# Altium schematic sheet main.SchDoc
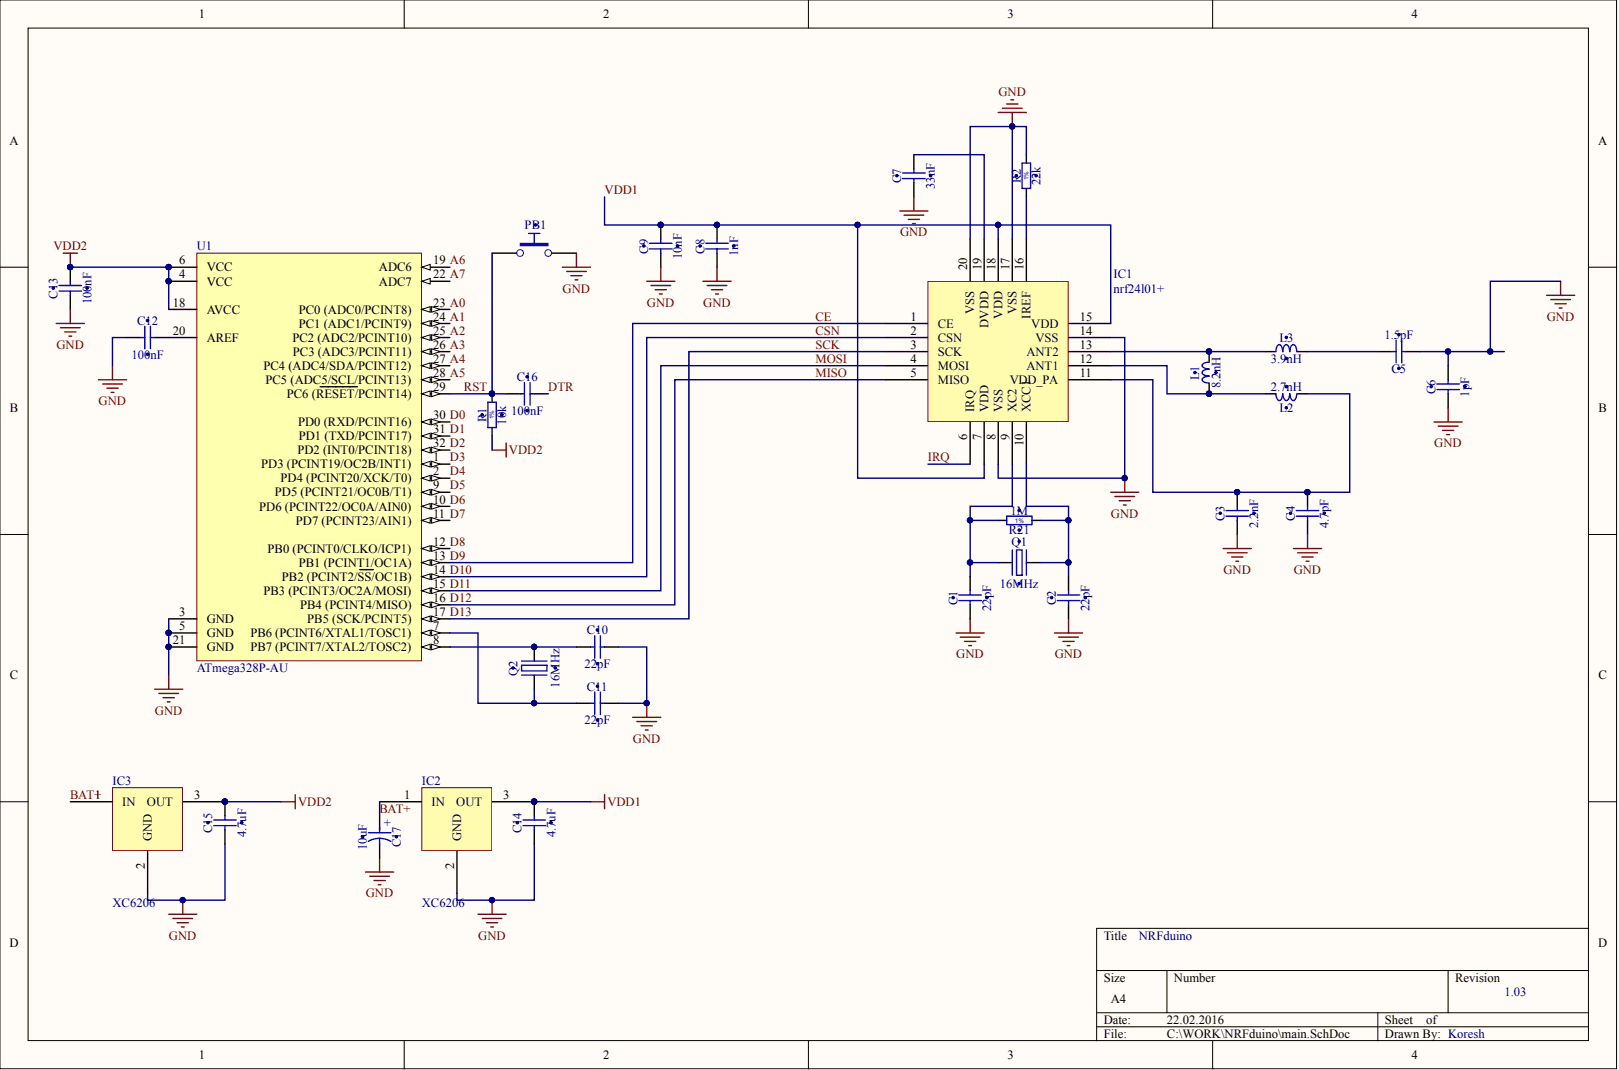
[diagram p0: main.SchDoc]

## Parts
C1  22pF  [CAPC1608X87N]
C2  22pF  [CAPC1608X87N]
C3  2.2nF  [CAPC1005X55N]
C4  4.7pF  [CAPC1005X55N]
C5  1.5pF  [CAPC1005X55N]
C6  1pF  [CAPC1005X55N]
C7  33nF  [CAPC1005X55N]
C8  1nF  [CAPC1005X55N]
C9  10nF  [CAPC1005X55N]
C10  22pF  [CAPC1608X87N]
C11  22pF  [CAPC1608X87N]
C12  100nF  [CAPC1608X87N]
C13  100nF  [CAPC1608X90N]
C14  4.7uF  [CAPC2012X135N]
C15  4.7uF  [CAPC2012X135N]
C16  100nF  [CAPC1608X87N]
C17  10uF  [CAPMP3216X180N]
IC1  nrf24l01+  [QFN-ML20_M]
IC2  XC6206  [XC6206]
IC3  XC6206  [XC6206]
L1  8.2nH  [INDC1005X55N]
L2  2.7nH  [INDC1005X55N]
L3  3.9nH  [INDC1005X55N]
PB1  Push Button  [PB_TYCO_FSMSM]  (value not drawn)
Q1  16MHz  [XTAL1140X470X160N]
Q2  16MHz  [XTAL_CITIZEN_HCM49]
R1  10k  [RESC1608X55N]
R2  22k  [RESC1005X40N]
R21  1M  [RESC1005X40N]
U1  ATmega328P-AU  [32A_N]

## Nets
GND: C1.1 C2.1 C3.1 C4.1 C5.1 C6.1 C6.2 C7.1 C8.1 C9.1 C10.2 C11.2 C12.1 C13.1 C14.1 C15.1 C17.2 IC1.8(VSS) IC1.14(VSS) IC1.17(VSS) IC1.20(VSS) IC2.2 IC3.2 PB1.2 R2.2 U1.3(GND) U1.5(GND) U1.21(GND)
VDD2: C13.2 C15.2 IC3.3 R1.1 U1.4(VCC) U1.6(VCC) U1.18(AVCC)
A0: U1.23(PC0_(ADC0/PCINT8))
A1: U1.24(PC1_(ADC1/PCINT9))
A2: U1.25
A3: U1.26(PC3_(ADC3/PCINT11))
A4: U1.27(PC4_(ADC4/SDA/PCINT12))
A5: U1.28(PC5_(ADC5/SCL/PCINT13))
A6: U1.19(ADC6)
A7: U1.22(ADC7)
BAT+: C17.1 IC2.1 IC3.1
CE: IC1.1(CE) U1.13(PB1_(PCINT1/OC1A))
CSN: IC1.2(CSN) U1.14(PB2_(PCINT2/SS/OC1B))
D0: U1.30(PD0_(RXD/PCINT16))
D1: U1.31(PD1_(TXD/PCINT17))
D2: U1.32(PD2_(INT0/PCINT18))
D3: U1.1(PD3_(PCINT19/OC2B/INT1))
D4: U1.2(PD4_(PCINT20/XCK/T0))
D5: U1.9(PD5_(PCINT21/OC0B/T1))
D6: U1.10(PD6_(PCINT22/OC0A/AIN0))
D7: U1.11(PD7_(PCINT23/AIN1))
D8: U1.12(PB0_(PCINT0/CLKO/ICP1))
D11: IC1.4(MOSI) U1.15(PB3_(PCINT3/OC2A/MOSI))
D12: IC1.5(MISO) U1.16(PB4_(PCINT4/MISO))
D13: IC1.3(SCK) U1.17(PB5_(SCK/PCINT5))
DTR: C16.2
IRQ: IC1.6(IRQ)
RST: C16.1 PB1.1 R1.2 U1.29(PC6_(RESET/PCINT14))
VDD1: C8.2 C9.2 C14.2 IC1.7(VDD) IC1.15(VDD) IC1.18(VDD) IC2.3
NetC1_2: C1.2 IC1.9(XC2) Q1.1 R21.2
NetC2_2: C2.2 IC1.10(XCC1) Q1.2 R21.1
NetC3_2: C3.2 C4.2 IC1.11(VDD_PA) L2.1
NetC5_2: C5.2 L3.2
NetC7_2: C7.2 IC1.19(DVDD)
NetC10_1: C10.1 Q2.2 U1.8
NetC11_1: C11.1 Q2.1 U1.7
NetC12_2: C12.2 U1.20(AREF)
NetIC1_12: IC1.12(ANT1) L1.1 L2.2
NetIC1_13: IC1.13(ANT2) L1.2 L3.1
NetIC1_16: IC1.16(IREF) R2.1

## Library data of the parts
C1 C2 C10 C11 = CC0603_22PF_50V_5%_NP0: Non-polarised capacitor; Manufacturer: GENERIC; Manufacturer1 Part Number: 223886715229; Part Description: SMD Multilayer Chip Ceramic Capacitor; Database Table Name: Capacitors SMD; TC: NP0; Tolerance: ±5%; Voltage: 50V; Case: 0603; Component Kind: Standard; Component Type: Standard; CreateDate: 03.12.2007; HelpURL: \\cern.ch\dfs\Applications\Altium\Datasheets\CC0603_NP0_PHYCOMP.pdf; LatestRevisionDate: 03.12.2007; Library Name: Capacitors.DbLib; Manufacturer1 ComponentHeight: 0.87mm; Manufacturer1 Example: PHYCOMP; Manufacturer Part Number: CC0603_22PF_50V_5%_NP0; Mounted: Yes; Part Number: CC0603_22PF_50V_5%_NP0; Pin Count: 2; SMD: Yes; Socket: No; Status: Preferred; Table: Capacitors SMD; Value: 22pF; Author: CERN DEM JLC
C3 = CC0402_2.2NF_50V_10%_X7R: Non-polarised capacitor; Manufacturer: GENERIC; Manufacturer1 Part Number: 223858715627; Part Description: SMD Multilayer Chip Ceramic Capacitor; Database Table Name: Capacitors SMD; TC: X7R; Tolerance: ±10%; Voltage: 50V; Case: 0402; Component Kind: Standard; Component Type: Standard; CreateDate: 03.12.2007; HelpURL: \\cern.ch\dfs\Applications\Altium\Datasheets\CC0402_X7R_PHYCOMP.pdf; LatestRevisionDate: 03.12.2007; Library Name: Capacitors.DbLib; Manufacturer1 ComponentHeight: 0.55mm; Manufacturer1 Example: PHYCOMP; Manufacturer Part Number: CC0402_2.2NF_50V_10%_X7R; Mounted: Yes; Part Number: CC0402_2.2NF_50V_10%_X7R; Pin Count: 2; SMD: Yes; Socket: No; Status: Not Recommended; Table: Capacitors SMD; Value: 2.2nF; Author: CERN DEM JLC
C4 = CC0402_4.7PF_50V_0.25PF_NP0: Non-polarised capacitor; Manufacturer: GENERIC; Manufacturer1 Part Number: 223886915478; Part Description: SMD Multilayer Chip Ceramic Capacitor; Database Table Name: Capacitors SMD; TC: NP0; Tolerance: ±0.25pF; Voltage: 50V; Case: 0402; Component Kind: Standard; Component Type: Standard; CreateDate: 03.12.2007; HelpURL: \\cern.ch\dfs\Applications\Altium\Datasheets\CC0402_NP0_PHYCOMP.pdf; LatestRevisionDate: 03.12.2007; Library Name: Capacitors.DbLib; Manufacturer1 ComponentHeight: 0.55mm; Manufacturer1 Example: PHYCOMP; Manufacturer Part Number: CC0402_4.7PF_50V_0.25PF_NP0; Mounted: Yes; Part Number: CC0402_4.7PF_50V_0.25PF_NP0; Pin Count: 2; SMD: Yes; Socket: No; Status: Not Recommended; Table: Capacitors SMD; Value: 4.7pF; Author: CERN DEM JLC
C5 = CC0402_1.5PF_50V_0.25PF_NP0: Non-polarised capacitor; Manufacturer: GENERIC; Manufacturer1 Part Number: 223886915158; Part Description: SMD Multilayer Chip Ceramic Capacitor; Database Table Name: Capacitors SMD; TC: NP0; Tolerance: ±0.25pF; Voltage: 50V; Case: 0402; Component Kind: Standard; Component Type: Standard; CreateDate: 03.12.2007; HelpURL: \\cern.ch\dfs\Applications\Altium\Datasheets\CC0402_NP0_PHYCOMP.pdf; LatestRevisionDate: 03.12.2007; Library Name: Capacitors.DbLib; Manufacturer1 ComponentHeight: 0.55mm; Manufacturer1 Example: PHYCOMP; Manufacturer Part Number: CC0402_1.5PF_50V_0.25PF_NP0; Mounted: Yes; Part Number: CC0402_1.5PF_50V_0.25PF_NP0; Pin Count: 2; SMD: Yes; Socket: No; Status: Not Recommended; Table: Capacitors SMD; Value: 1.5pF; Author: CERN DEM JLC
C6 = CC0402_1PF_50V_0.25PF_NP0: Non-polarised capacitor; Manufacturer: GENERIC; Manufacturer1 Part Number: 223886915108; Part Description: SMD Multilayer Chip Ceramic Capacitor; Database Table Name: Capacitors SMD; TC: NP0; Tolerance: ±0.25pF; Voltage: 50V; Case: 0402; Component Kind: Standard; Component Type: Standard; CreateDate: 03.12.2007; HelpURL: \\cern.ch\dfs\Applications\Altium\Datasheets\CC0402_NP0_PHYCOMP.pdf; LatestRevisionDate: 03.12.2007; Library Name: Capacitors.DbLib; Manufacturer1 ComponentHeight: 0.55mm; Manufacturer1 Example: PHYCOMP; Manufacturer Part Number: CC0402_1PF_50V_0.25PF_NP0; Mounted: Yes; Part Number: CC0402_1PF_50V_0.25PF_NP0; Pin Count: 2; SMD: Yes; Socket: No; Status: Not Recommended; Table: Capacitors SMD; Value: 1pF; Author: CERN DEM JLC
C7 = CC0402_33NF_16V_10%_X7R: Non-polarised capacitor; Manufacturer: GENERIC; Manufacturer1 Part Number: 223878715643; Part Description: SMD Multilayer Chip Ceramic Capacitor; Database Table Name: Capacitors SMD; TC: X7R; Tolerance: ±10%; Voltage: 16V; Case: 0402; Component Kind: Standard; Component Type: Standard; CreateDate: 03.12.2007; HelpURL: \\cern.ch\dfs\Applications\Altium\Datasheets\CC0402_X7R_PHYCOMP.pdf; LatestRevisionDate: 03.12.2007; Library Name: Capacitors.DbLib; Manufacturer1 ComponentHeight: 0.55mm; Manufacturer1 Example: PHYCOMP; Manufacturer Part Number: CC0402_33NF_16V_10%_X7R; Mounted: Yes; Part Number: CC0402_33NF_16V_10%_X7R; Pin Count: 2; SMD: Yes; Socket: No; Status: Not Recommended; Table: Capacitors SMD; Value: 33nF; Author: CERN DEM JLC
C8 = CC0402_1NF_50V_10%_X7R: Non-polarised capacitor; Manufacturer: GENERIC; Manufacturer1 Part Number: 223858715623; Part Description: SMD Multilayer Chip Ceramic Capacitor; Database Table Name: Capacitors SMD; TC: X7R; Tolerance: ±10%; Voltage: 50V; Case: 0402; Component Kind: Standard; Component Type: Standard; CreateDate: 03.12.2007; HelpURL: \\cern.ch\dfs\Applications\Altium\Datasheets\CC0402_X7R_PHYCOMP.pdf; LatestRevisionDate: 03.12.2007; Library Name: Capacitors.DbLib; Manufacturer1 ComponentHeight: 0.55mm; Manufacturer1 Example: PHYCOMP; Manufacturer Part Number: CC0402_1NF_50V_10%_X7R; Mounted: Yes; Part Number: CC0402_1NF_50V_10%_X7R; Pin Count: 2; SMD: Yes; Socket: No; Status: Not Recommended; Table: Capacitors SMD; Value: 1nF; Author: CERN DEM JLC
C9 = CC0402_10NF_16V_10%_X7R: Non-polarised capacitor; Manufacturer: GENERIC; Manufacturer1 Part Number: 223878715636; Part Description: SMD Multilayer Chip Ceramic Capacitor; Database Table Name: Capacitors SMD; TC: X7R; Tolerance: ±10%; Voltage: 16V; Case: 0402; Component Kind: Standard; Component Type: Standard; CreateDate: 03.12.2007; HelpURL: \\cern.ch\dfs\Applications\Altium\Datasheets\CC0402_X7R_PHYCOMP.pdf; LatestRevisionDate: 03.12.2007; Library Name: Capacitors.DbLib; Manufacturer1 ComponentHeight: 0.55mm; Manufacturer1 Example: PHYCOMP; Manufacturer Part Number: CC0402_10NF_16V_10%_X7R; Mounted: Yes; Part Number: CC0402_10NF_16V_10%_X7R; Pin Count: 2; SMD: Yes; Socket: No; Status: Not Recommended; Table: Capacitors SMD; Value: 10nF; Author: CERN DEM JLC
C12 C16 = CC0603_100NF_50V_10%_X7R: Non-polarised capacitor; Manufacturer: GENERIC; Manufacturer1 Part Number: 223858615649; Part Description: SMD Multilayer Chip Ceramic Capacitor; Database Table Name: Capacitors SMD; TC: X7R; Tolerance: ±10%; Voltage: 50V; Case: 0603; Component Kind: Standard; Component Type: Standard; CreateDate: 03.12.2007; HelpURL: \\cern.ch\dfs\Applications\Altium\Datasheets\CC0603_X7R_PHYCOMP.pdf; LatestRevisionDate: 03.12.2007; Library Name: Capacitors.DbLib; Manufacturer1 ComponentHeight: 0.87mm; Manufacturer1 Example: PHYCOMP; Manufacturer Part Number: CC0603_100NF_50V_10%_X7R; Mounted: Yes; Part Number: CC0603_100NF_50V_10%_X7R; Pin Count: 2; SMD: Yes; Socket: No; Status: Preferred; Table: Capacitors SMD; Value: 100nF; Author: CERN DEM JLC
C13 = CC0603_100NF_100V_10%_X7R: Non-polarised capacitor; Manufacturer: GENERIC; Manufacturer1 Part Number: GRM188R72A104KA35D; Part Description: SMD Multilayer Chip Ceramic Capacitor; Database Table Name: Capacitors SMD; TC: X7R; Tolerance: ±10%; Voltage: 100V; Case: 0603; Component Kind: Standard; Component Type: Standard; CreateDate: 11.12.2013; HelpURL: \\cern.ch\dfs\Applications\Altium\Datasheets\CC0603_MURATA_GRM188R72A104KA35D.pdf; LatestRevisionDate: 11.12.2013; Library Name: Capacitors.DbLib; Manufacturer1 ComponentHeight: 0.9mm; Manufacturer1 Example: MURATA; Manufacturer Part Number: CC0603_100NF_100V_10%_X7R; Mounted: Yes; Part Number: CC0603_100NF_100V_10%_X7R; Pin Count: 2; SMD: Yes; Socket: No; Status: None; Table: Capacitors SMD; Value: 100nF; Author: CERN DEM JLC
C14 C15 = CC0805_4.7UF_10V_10%_X5R: Non-polarised capacitor; Manufacturer: GENERIC; Manufacturer1 Part Number: 222224013672; Part Description: SMD Multilayer Chip Ceramic Capacitor; Database Table Name: Capacitors SMD; TC: X5R; Tolerance: ±10%; Voltage: 10V; Case: 0805; Component Kind: Standard; Component Type: Standard; CreateDate: 03.12.2007; HelpURL: \\cern.ch\dfs\Applications\Altium\Datasheets\CC0805_X5R_PHYCOMP_HC.pdf; LatestRevisionDate: 03.12.2007; Library Name: Capacitors.DbLib; Manufacturer1 ComponentHeight: 1.45mm; Manufacturer1 Example: PHYCOMP; Manufacturer Part Number: CC0805_4.7UF_10V_10%_X5R; Mounted: Yes; Part Number: CC0805_4.7UF_10V_10%_X5R; Pin Count: 2; SMD: Yes; Socket: No; Status: Preferred; Table: Capacitors SMD; Value: 4.7uF; Author: CERN DEM JLC
C17 = CTE3216-18_10UF_16V_20%_AVX_TCJ: Polarised capacitor; Manufacturer: AVX; Manufacturer Part Number: TCJA106M016R0200; Part Description: ESR 0R200 Tantalum Solid Electrolytic Chip Capacitor with Conductive Polymer Electrode; Database Table Name: Capacitors SMD; Tolerance: ±20%; Voltage: 16V; Case: A; ComponentHeight: 1.8mm; Component Kind: Standard; Component Type: Standard; CreateDate: 29.03.2012; HelpURL: \\cern.ch\dfs\Applications\Altium\Datasheets\CTE_AVX_TCJ.pdf; LatestRevisionDate: 29.03.2012; Library Name: Capacitors.DbLib; Mounted: Yes; Part Number: CTE3216-18_10UF_16V_20%_AVX_TCJ; Pin Count: 2; SMD: Yes; Socket: No; Status: Preferred; Table: Capacitors SMD; Value: 10uF; Author: CERN DEM JLC
L1 = IND0402_MURATA_BLM15HB221SN1: Inductor; Manufacturer: MURATA; Part Description: SMD EMIFIL Suppression Chip Ferrite Bead; Database Table Name: Inductors SMD; Power: 250mA; Resistance: 1R; Tolerance: ±25%; Case: 0402; ComponentHeight: 0.55mm; Component Kind: Standard; Component Type: Standard; CreateDate: 23.06.2009; HelpURL: \\cern.ch\dfs\Applications\Altium\Datasheets\IND0402_MURATA_BLM15H.pdf; LatestRevisionDate: 23.06.2009; Library Name: Inductors & Transformers.DbLib; Manufacturer Part Number: BLM15HB221SN1; Mounted: Yes; Part Number: IND0402_MURATA_BLM15HB221SN1; Pin Count: 2; SMD: Yes; Socket: No; Status: None; Table: Inductors SMD; Value: 8.2nH; Author: CERN DEM JLC
L2 = IND0402_MURATA_BLM15PX121SN1D: Inductor; Manufacturer: MURATA; Part Description: SMD EMIFIL Chip Ferrite Bead; Database Table Name: Inductors SMD; Power: 2A; Resistance: 0.055R; Tolerance: ±25%; Case: 0402; ComponentHeight: 0.55mm; Component Kind: Standard; Component Type: Standard; CreateDate: 19.02.2014; HelpURL: \\cern.ch\dfs\Applications\Altium\Datasheets\IND0402_MURATA_BLM15PX.pdf; LatestRevisionDate: 19.02.2014; Library Name: Inductors & Transformers.DbLib; Manufacturer Part Number: BLM15PX121SN1D; Mounted: Yes; Part Number: IND0402_MURATA_BLM15PX121SN1D; Pin Count: 2; SMD: Yes; Socket: No; Status: None; Table: Inductors SMD; Value: 2.7nH; Author: CERN DEM JMW
L3 = IND0402_MURATA_BLM15HD102SN1: Inductor; Manufacturer: MURATA; Manufacturer Part Number: BLM15HD102SN1D; Part Description: SMD EMIFIL Suppression Chip Ferrite Bead; Database Table Name: Inductors SMD; Power: 250mA; Resistance: 1.25R; Tolerance: ±25%; Case: 0402; ComponentHeight: 0.55mm; Component Kind: Standard; Component Type: Standard; CreateDate: 06.03.2012; HelpURL: \\cern.ch\dfs\Applications\Altium\Datasheets\IND0402_MURATA_BLM15H.pdf; LatestRevisionDate: 06.03.2012; Library Name: Inductors & Transformers.DbLib; Mounted: Yes; Part Number: IND0402_MURATA_BLM15HD102SN1; Pin Count: 2; SMD: Yes; Socket: No; Status: None; Table: Inductors SMD; Value: 3.9nH; Author: CERN DEM JLC
PB1 = PB_TYCO_FSMSM: Push-Button Switch SPDT; Manufacturer: TYCO ELECTRONICS; Manufacturer Part Number: FSMSM or 1437566-3; Part Description: 12VDC 50mA SPST Tactile  Push-Button Switch; Database Table Name: Switches; ComponentHeight: 4.3mm; Component Kind: Standard; Component Type: Standard; CreateDate: 30.06.2008; Family: Push Button; HelpURL: \\cern.ch\dfs\Applications\Altium\Datasheets\PB_TYCO_FSMSM.pdf; LatestRevisionDate: 21.06.2012; Library Name: Eletro-mechanical.DbLib; Mounted: Yes; PackageDescription: Body 3.5x6.0mm, Pushbutton Switch,  TYCO ALCOSWITCH FSMSM Serie; Part Number: PB_TYCO_FSMSM; Pin Count: 2; SMD: Yes; Socket: No; Status: None; Table: Switches; Author: CERN DEM JLC
Q1 = XTAL_25MHZ_ABRACON_ABLS-25.000MHZ-B2F-T: Quartz Crystal; Part Description: ±20ppm Low Profile Surface Mount Microprocessor Crystal, HC49 US ABLS Serie; Database Table Name: Crystals & Oscillators; ComponentHeight: 1.6mm; Component Kind: Standard; Component Type: Standard; CreateDate: 03.06.2010; HelpURL: \\cern.ch\dfs\Applications\Altium\Datasheets\XTAL_ABRACON_ABLS.pdf; LatestRevisionDate: 09.01.2012; Library Name: ICs And Semiconductors.DbLib; Manufacturer: ABRACON; Manufacturer Part Number: ABLS-25.000MHZ-B2F-T; Mounted: Yes; PackageDescription: HC49US Crystal;11.40mm L X 4.70mm W X 1.60mm H; Part Number: XTAL_25MHZ_ABRACON_ABLS-25.000MHZ-B2F-T; Pin Count: 2; SMD: Yes; Socket: No; Table: Crystals & Oscillators; Value: 16MHz; Author: CERN DEM JLC
Q2 = XTAL_24MHZ_CITIZEN_HCM49-24.000MABJT: Quartz Crystal; Manufacturer: CITIZEN FINETECH MIYOTA CO; Part Description: Tolerance:± 30ppm, Stability:± 50ppm, -10°C to +60°C, 18pF, AT-CUT Crystal Unit, HCM49 Series; Database Table Name: Crystals & Oscillators; ComponentHeight: 4.3mm; Component Kind: Standard; Component Type: Standard; CreateDate: 22.07.2014; HelpURL: \\cern.ch\dfs\Applications\Altium\Datasheets\XTAL_CITIZEN_HCM49.pdf; LatestRevisionDate: 22.07.2014; Library Name: ICs And Semiconductors.DbLib; Manufacturer Part Number: HCM49-24.000MABJT; Mounted: Yes; PackageDescription: CITIZEN HCM49 Case, SMD Cristal, Body 11.4 x 4.7mm; Part Number: XTAL_24MHZ_CITIZEN_HCM49-24.000MABJT; Pin Count: 2; SMD: No; Socket: No; Table: Crystals & Oscillators; Value: 16MHz; Author: CERN DEM JLC
R1 = R0603_10K_1%_0.1W_100PPM: Resistor - 1%; Manufacturer: GENERIC; Manufacturer1 Part Number: NRC06F1002TRF; Part Description: General Purpose Thick Film Chip Resistor; Database Table Name: Resistors SMD; Power: 0.1W; TC: ±100ppm/°C; Case: 0603; Component Kind: Standard; Component Type: Standard; CreateDate: 03.12.2007; HelpURL: \\cern.ch\dfs\Applications\Altium\Datasheets\R0603_NIC_NRC.pdf; LatestRevisionDate: 13.03.2008; Library Name: Resistors.DbLib; Manufacturer1 ComponentHeight: 0.55mm; Manufacturer1 Example: NIC COMPONENT; Manufacturer Part Number: R0603_10K_1%_0.1W_100PPM; Mounted: Yes; Part Number: R0603_10K_1%_0.1W_100PPM; Pin Count: 2; SMD: Yes; Socket: No; Status: Preferred; Table: Resistors SMD; Tolerance: ±1%; Value: 10k; Author: CERN DEM JLC
R2 = R0402_22K_1%_0.0625W_100PPM: Resistor - 1%; Manufacturer: GENERIC; Manufacturer1 Part Number: 232270672203L; Part Description: General Purpose Thick Film Chip Resistor; Database Table Name: Resistors SMD; TC: ±100ppm/°C; Case: 0402; Component Kind: Standard; Component Type: Standard; CreateDate: 03.12.2007; HelpURL: \\cern.ch\dfs\Applications\Altium\Datasheets\R0402_Phycomp_RC0402.pdf; LatestRevisionDate: 17.10.2012; Library Name: Resistors.DbLib; Manufacturer1 ComponentHeight: 0.4mm; Manufacturer1 Example: YAGEO PHYCOMP; Manufacturer Part Number: R0402_22K_1%_0.0625W_100PPM; Mounted: Yes; Part Number: R0402_22K_1%_0.0625W_100PPM; Pin Count: 2; Power: 0.0625W; SMD: Yes; Socket: No; Status: Not recommended; Table: Resistors SMD; Tolerance: ±1%; Value: 22k; Author: CERN DEM JLC
R21 = R0402_1M_1%_0.0625W_100PPM: Resistor - 1%; Manufacturer: GENERIC; Manufacturer1 Part Number: 232270671005L; Part Description: General Purpose Thick Film Chip Resistor; Database Table Name: Resistors SMD; TC: ±100ppm/°C; Case: 0402; Component Kind: Standard; Component Type: Standard; CreateDate: 03.12.2007; HelpURL: \\cern.ch\dfs\Applications\Altium\Datasheets\R0402_Phycomp_RC0402.pdf; LatestRevisionDate: 17.10.2012; Library Name: Resistors.DbLib; Manufacturer1 ComponentHeight: 0.4mm; Manufacturer1 Example: YAGEO PHYCOMP; Manufacturer Part Number: R0402_1M_1%_0.0625W_100PPM; Mounted: Yes; Part Number: R0402_1M_1%_0.0625W_100PPM; Pin Count: 2; Power: 0.0625W; SMD: Yes; Socket: No; Status: Not recommended; Table: Resistors SMD; Tolerance: ±1%; Value: 1M; Author: CERN DEM JLC
U1 = ATmega328P-AU: 8-bit AVR Microcontroller, 32KB Flash, 1KB EEPROM, 2KB SRAM, 32-pin TQFP, Industrial Grade (-40°C to 85°C); Code_JEDEC: MS-026-ABA; Component Kind: Standard; ComponentLink1Description: Manufacturer URL; ComponentLink1URL: http://www.atmel.com/; ComponentLink2Description: ATmega48A/48PA/88A/88PA/168A/168PA/328/328P Datasheet; ComponentLink2URL: http://www.atmel.com/dyn/resources/prod_documents/doc8271.pdf; ComponentLink3Description: ATmega48A/48PA/88A/88PA/168A/168PA/328/328P Summary; ComponentLink3URL: http://www.atmel.com/dyn/resources/prod_documents/8271S.pdf; DatasheetVersion: revD, May-2011; Library Name: Atmel Microcontroller megaAVR.IntLib; PackageDescription: 32-Lead, 7x7x1.0 mm Body, 0.8mm Lead Pitch, Thin Profile Plastic Quad Flat Package (TQFP); PackageReference: 32A; PackageVersion: revC, Oct-2010
IC1 = nrf24l01+: Component Kind: Standard; Library Name: nrfduino.SchLib
IC2 IC3 = XC6206: Component Kind: Standard; Library Name: alarm_remote.SchLib
